# Altium schematic sheet AES-MS-MT3620-SK-G_PIO.SchDoc(AES-MS-MT3620-SK-G_PIO)
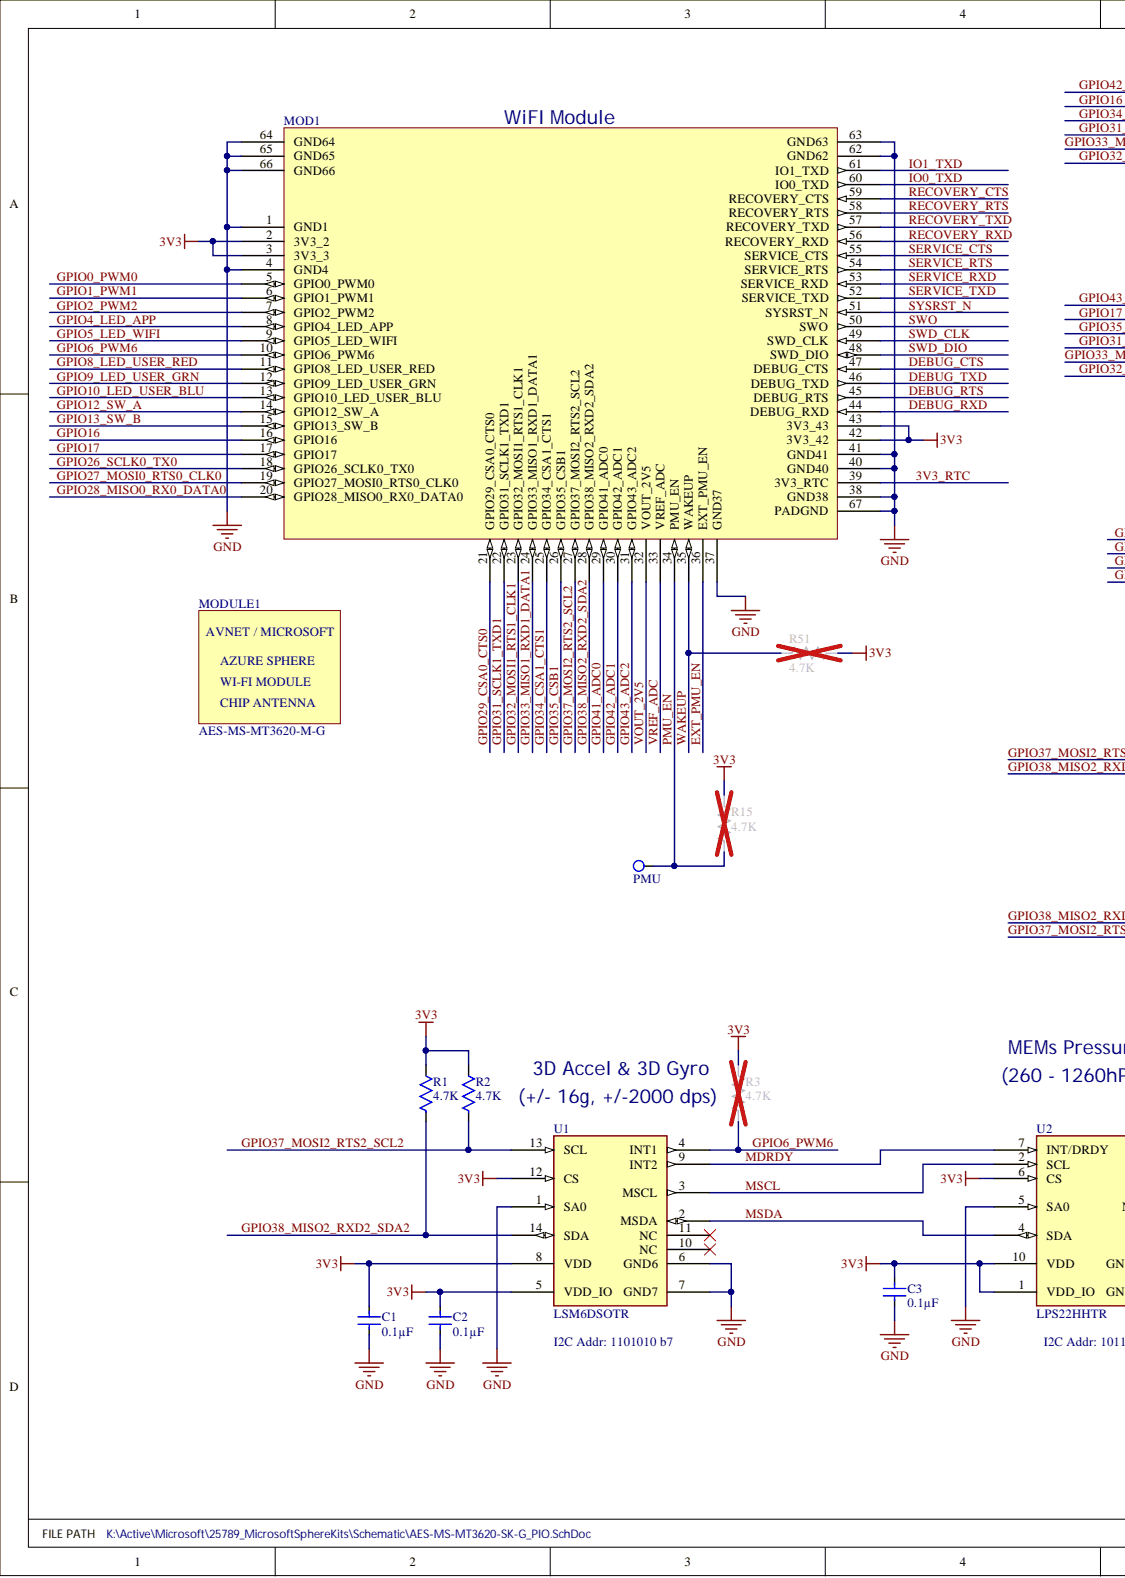
[diagram p0: AES-MS-MT3620-SK-G_PIO.SchDoc, left]
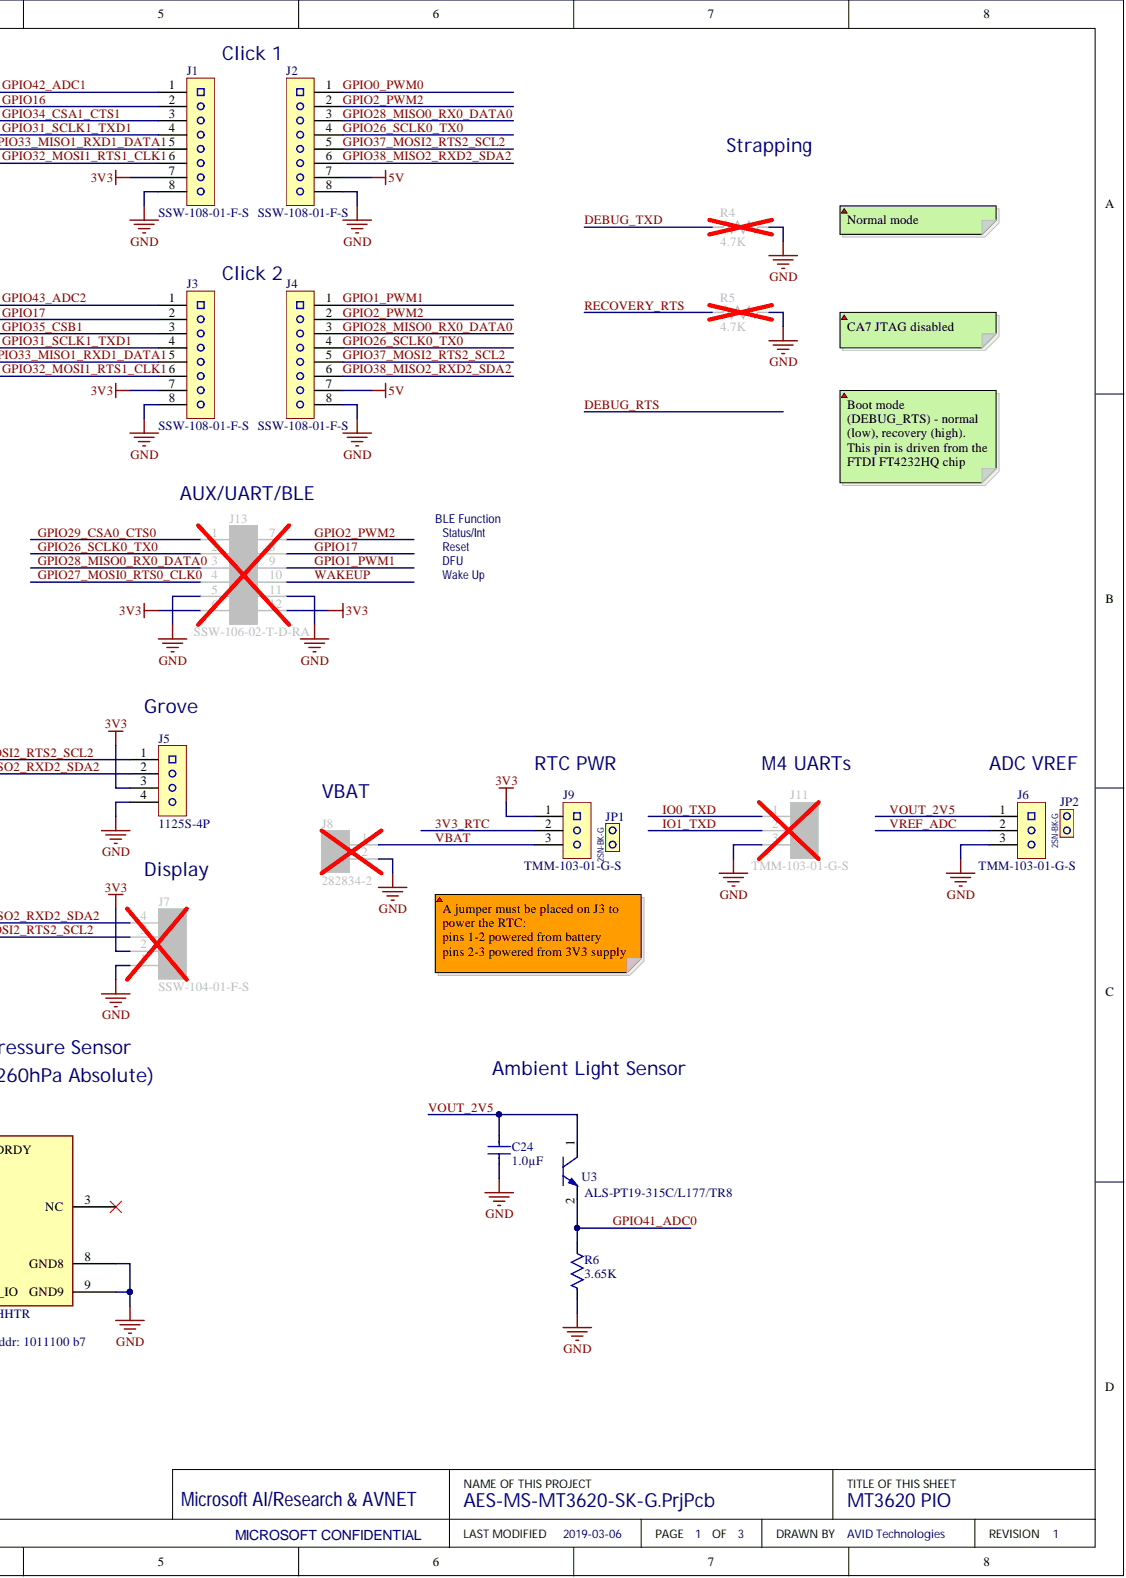
[diagram p1: AES-MS-MT3620-SK-G_PIO.SchDoc, right]

## Parts
C1  Capacitance: 0.1µF  [CAPC1005-EIA0402 0.55MM MED]  (hidden comment: 0.1uF)
C2  Capacitance: 0.1µF  [CAPC1005-EIA0402 0.55MM MED]  (hidden comment: 0.1uF)
C3  Capacitance: 0.1µF  [CAPC1005-EIA0402 0.55MM MED]  (hidden comment: 0.1uF)
C24  1.0µF  [CAPC1005-EIA0402 0.55MM MED]
J1  SSW-108-01-F-S  [SSW-108-01-F-S]
J2  SSW-108-01-F-S  [SSW-108-01-F-S]
J3  SSW-108-01-F-S  [SSW-108-01-F-S]
J4  SSW-108-01-F-S  [SSW-108-01-F-S]
J5  1125S-4P  [1125S-4P]
J6  TMM-103-01-G-S  [TMM-103-01-G-S]
J7  SSW-104-01-F-S  [SSW-104-01-F-S]
J8  282834-2  [282834-2-TB]
J9  TMM-103-01-G-S  [TMM-103-01-G-S]
J11  TMM-103-01-G-S  [TMM-103-01-G-S]
J13  SSW-106-02-T-D-RA  [CONN_SAMTEC_SSW-106-02-T-D-RA]
JP1  Manufacturer Part Number 1: 2SN-BK-G  (hidden comment: SHUNT 2POS 2mm)  (mechanical)
JP2  Manufacturer Part Number 1: 2SN-BK-G  (hidden comment: SHUNT 2POS 2mm)  (mechanical)
MOD1  MOD_AES-MS-MT3620  [AES-MS-MT3620-M-G_MODULE - 1.2]  (value not drawn)  (no BOM)
MODULE1  AES-MS-MT3620-M-G  Manufacturer 1: AVNET
PMU  TestPoint  [Pad1.27mm]  (value not drawn)  (no BOM)
R1  4.7K  [RES 0402 MEDIUM DENSITY]
R2  4.7K  [RES 0402 MEDIUM DENSITY]
R3  4.7K  [RES 0402 MEDIUM DENSITY]
R4  4.7K  [RES 0402 MEDIUM DENSITY]
R5  4.7K  [RES 0402 MEDIUM DENSITY]
R6  3.65K  [RES 0402 MEDIUM DENSITY]
R15  4.7K  [RES 0402 MEDIUM DENSITY]
R51  4.7K  [RES 0402 MEDIUM DENSITY]
U1  LSM6DSOTR  [LGA-14 2.5x3x0.86]
U2  LPS22HHTR  [LGA-10 2x2x0.8]
U3  ALS-PT19-315C/L177/TR8  [ALS-PT19-315C/L177/TR8]

## Nets
3V3: C1.2 C2.2 C3.2 J1.7 J3.7 J5.3 J7.2 J9.1 J13.6 J13.12 MOD1.2(3V3_2) MOD1.3(3V3_3) MOD1.42(3V3_42) MOD1.43(3V3_43) R1.1 R2.1 R3.1 R15.1 R51.1 U1.5(VDD_IO) U1.8(VDD) U1.12(CS) U2.1(VDD_IO) U2.6(CS) U2.10(VDD)
5V: J2.7 J4.7
GND: C1.1 C2.1 C3.1 C24.1 J1.8 J2.8 J3.8 J4.8 J5.4 J6.3 J7.1 J8.2 J11.3 J13.5 J13.11 MOD1.1(GND1) MOD1.4(GND4) MOD1.37(GND37) MOD1.38(GND38) MOD1.40(GND40) MOD1.41(GND41) MOD1.62(GND62) MOD1.63(GND63) MOD1.64(GND64) MOD1.65(GND65) MOD1.66(GND66) MOD1.67(PADGND) R4.1 R5.1 R6.2 U1.1(SA0) U1.6(GND6) U1.7(GND7) U2.5(SA0) U2.8(GND8) U2.9(GND9)
3V3_RTC: J9.2 MOD1.39(3V3_RTC)
DEBUG_CTS: MOD1.47(DEBUG_CTS)
DEBUG_RTS: MOD1.45(DEBUG_RTS)
DEBUG_RXD: MOD1.44(DEBUG_RXD)
DEBUG_TXD: MOD1.46(DEBUG_TXD) R4.2
EXT_PMU_EN: MOD1.36(EXT_PMU_EN)
GPIO0_PWM0: J2.1 MOD1.5(GPIO0_PWM0)
GPIO1_PWM1: J4.1 J13.9 MOD1.6(GPIO1_PWM1)
GPIO2_PWM2: J2.2 J4.2 J13.7 MOD1.7(GPIO2_PWM2)
GPIO4_LED_APP: MOD1.8(GPIO4_LED_APP)
GPIO5_LED_WIFI: MOD1.9(GPIO5_LED_WIFI)
GPIO6_PWM6: MOD1.10(GPIO6_PWM6) R3.2 U1.4(INT1)
GPIO8_LED_USER_RED: MOD1.11(GPIO8_LED_USER_RED)
GPIO9_LED_USER_GRN: MOD1.12(GPIO9_LED_USER_GRN)
GPIO10_LED_USER_BLU: MOD1.13(GPIO10_LED_USER_BLU)
GPIO12_SW_A: MOD1.14(GPIO12_SW_A)
GPIO13_SW_B: MOD1.15(GPIO13_SW_B)
GPIO16: J1.2 MOD1.16(GPIO16)
GPIO17: J3.2 J13.8 MOD1.17(GPIO17)
GPIO26_SCLK0_TX0: J2.4 J4.4 J13.2 MOD1.18(GPIO26_SCLK0_TX0)
GPIO27_MOSI0_RTS0_CLK0: J13.4 MOD1.19(GPIO27_MOSI0_RTS0_CLK0)
GPIO28_MISO0_RX0_DATA0: J2.3 J4.3 J13.3 MOD1.20(GPIO28_MISO0_RX0_DATA0)
GPIO29_CSA0_CTS0: J13.1 MOD1.21(GPIO29_CSA0_CTS0)
GPIO31_SCLK1_TXD1: J1.4 J3.4 MOD1.22(GPIO31_SCLK1_TXD1)
GPIO32_MOSI1_RTS1_CLK1: J1.6 J3.6 MOD1.23(GPIO32_MOSI1_RTS1_CLK1)
GPIO33_MISO1_RXD1_DATA1: J1.5 J3.5 MOD1.24(GPIO33_MISO1_RXD1_DATA1)
GPIO34_CSA1_CTS1: J1.3 MOD1.25(GPIO34_CSA1_CTS1)
GPIO35_CSB1: J3.3 MOD1.26(GPIO35_CSB1)
GPIO37_MOSI2_RTS2_SCL2: J2.5 J4.5 J5.1 J7.3 MOD1.27(GPIO37_MOSI2_RTS2_SCL2) R2.2 U1.13(SCL)
GPIO38_MISO2_RXD2_SDA2: J2.6 J4.6 J5.2 J7.4 MOD1.28(GPIO38_MISO2_RXD2_SDA2) R1.2 U1.14(SDA)
GPIO41_ADC0: MOD1.29(GPIO41_ADC0) R6.1 U3.2
GPIO42_ADC1: J1.1 MOD1.30(GPIO42_ADC1)
GPIO43_ADC2: J3.1 MOD1.31(GPIO43_ADC2)
IO0_TXD: J11.1 MOD1.60(IO0_TXD)
IO1_TXD: J11.2 MOD1.61(IO1_TXD)
MDRDY: U1.9(INT2) U2.7(INT/DRDY)
MSCL: U1.3(MSCL) U2.2(SCL)
MSDA: U1.2(MSDA) U2.4(SDA)
PMU_EN: MOD1.34(PMU_EN) PMU.1 R15.2
RECOVERY_CTS: MOD1.59(RECOVERY_CTS)
RECOVERY_RTS: MOD1.58(RECOVERY_RTS) R5.2
RECOVERY_RXD: MOD1.56(RECOVERY_RXD)
RECOVERY_TXD: MOD1.57(RECOVERY_TXD)
SERVICE_CTS: MOD1.55(SERVICE_CTS)
SERVICE_RTS: MOD1.54(SERVICE_RTS)
SERVICE_RXD: MOD1.53(SERVICE_RXD)
SERVICE_TXD: MOD1.52(SERVICE_TXD)
SWD_CLK: MOD1.49(SWD_CLK)
SWD_DIO: MOD1.48(SWD_DIO)
SWO: MOD1.50(SWO)
SYSRST_N: MOD1.51(SYSRST_N)
VBAT: J8.1 J9.3
VOUT_2V5: C24.2 J6.1 MOD1.32(VOUT_2V5) U3.1
VREF_ADC: J6.2 MOD1.33(VREF_ADC)
WAKEUP: J13.10 MOD1.35(WAKEUP) R51.2

Not connected: U1 10(NC) 11(NC); U2 3(NC)

## Library data of the parts
C1-C3 = 0.1µF 0402 X7R 50V: CAP CER 0.1UF 25V 10% X5R 0402; Manufacturer Part Number 1: CL05A104KA5NNNC; Manufacturer 1: Samsung; Width: 0.02inch; Voltage Rating (DC): 25V; Voltage Rating: 25V; Voltage: 25V; Tolerance: 10%; Thickness: 0.022inch; Supplier Part Number 1: 1276-1043-1-ND; Supplier 1: Digi-Key; Stock 1: 100000; Radiation Hardening: No; Pricing 1: 1=0.1, 10=0.032, 100=0.0141, 500=0.0101, 1000=0.0079, 2500=0.0072, 5000=0.0066 (USD); Packaging: Tape and Reel; Package Quantity: 1; Mount: Surface Mount; Min Operating Temperature: -55°C; Max Operating Temperature: 85°C; Length: 1mm; Lead Free: Lead Free; Height: 0.5mm; Dielectric: X5R; Depth: 0.5mm; Component Kind: Standard; Case Code (Metric): 1005; Case Code (Imperial): 0402; Case/Package: 0402; Library Name: Sphere.SCHLIB
C24 = 1.0µF 0402 X5R 35V: CAP CER 1UF 35V 10% X5R 0402; Manufacturer Part Number 1: C1005X5R1V105K050BC; Manufacturer 1: TDK Corporation; Voltage - Rated: 35V; Tolerance: ±10%; Thickness (Max): 0.022" (0.55mm); Temperature Coefficient: X5R; Supplier Part Number 1: 445-9073-2-ND; Supplier 1: Digi-Key; Stock 1: 47833; Size / Dimension: 0.039" L x 0.020" W (1.00mm x 0.50mm); RoHS: RoHS Compliant; Ratings: -; Pricing 1: 0.0186; Packaging: Tape & Reel (TR); Package / Case: 0402 (1005 Metric); Operating Temperature: -55°C ~ 125°C; Mounting Type: Surface Mount, MLCC; Lead Style: -; Lead Spacing: -; Height - Seated (Max): -; Features: -; Component Kind: Standard; Category: Capacitors; Capacitance: 1.0µF; Applications: General Purpose; Library Name: Sphere.SCHLIB
J1-J4 = CONN 8 POS SSW-108-01-F-S: CONN RCPT .100" 8POS SNGL GOLD; Manufacturer 1: Samtec; Pitch: 2.54mm; Number of Contacts: 8; Max Voltage Rating (AC): 550V; Max Current Rating: 7.6A; Mating Cycles: 100; Gender: Female; Contact Resistance: 10mR; Termination: Solder; Supplier Part Number 1: SAM11943-ND; Supplier 1: Digi-Key; Stock 1: 256; Radiation Hardening: No; Pricing 1: 1=1.19, 10=0.988, 100=0.732, 500=0.5856, 1000=0.5307, 5000=0.4667, 10000=0.4575 (USD); Packaging: Bulk; Orientation: Straight; Number of Rows: 1; Min Operating Temperature: -55°C; Max Operating Temperature: 125°C; Manufacturer Part Number 1: SSW-108-01-F-S; Length: 20.83mm; Housing Material: Polymer; Housing Colour: Black; Depth: 2.41mm; Contact Plating: Gold; Contact Material: Bronze; Component Kind: Standard; Colour: Black; Library Name: Sphere.SCHLIB
J5 = CONN_4POS_2mm_1125S-4P: CONN HEADER 4POS 2mm VERT; Manufacturer 1: NS-TECH; Supplier Part Number 1: 110990046-ND; Supplier 1: Digi-Key; Manufacturer Part Number 1: 1125S-4P; Component Kind: Standard; Library Name: Sphere.SCHLIB
J6 J9 J11 = CONN 3 POS PINHDR 2MM: CONN HEADER 3POS SNGL 2MM T/H; Manufacturer 1: Samtec; Voltage Rating: 500V; Number of Positions: 3; Max Current Rating: 3.9A; Gender: Male; Connector Type: Male Pin; Termination: Solder; Supplier Part Number 1: SAM1174-03-ND; Supplier 1: Digi-Key; Stock 1: 14838; REACH SVHC: No SVHC; Radiation Hardening: No; Pricing 1: 1=1.76, 10=1.526, 100=1.2908, 500=1.0268, 1000=0.8361, 5000=0.7628, 10000=0.7334 (USD); Pitch: 2mm; Packaging: Bulk; Package Quantity: 1; Orientation: Straight; Number of Rows: 1; Number of Contacts: 3; Mount: Through Hole; Min Operating Temperature: -55°C; Max Voltage Rating (AC): 500V; Max Operating Temperature: 125°C; Manufacturer Part Number 1: TMM-103-01-G-S; Length: 6mm; Lead Free: Lead Free; Housing Material: Polymer; Housing Colour: Black; Depth: 1.97mm; Current Rating: 3.9A; Contact Plating: Gold; Contact Mating Length: 0.126inch; Contact Material: Bronze; Component Kind: Standard; Colour: Black; Library Name: Sphere.SCHLIB
J7 = CONN 4 POS SSW-104-01-F-S: CONN RCPT .100" 4POS SNGL GOLD; Manufacturer 1: Samtec; Pitch: 2.54mm; Number of Contacts: 4; Max Voltage Rating (AC): 550V; Max Current Rating: 6.9A; Mating Cycles: 100; Gender: Female; Contact Resistance: 10mR; Termination: Solder; Supplier Part Number 1: SAM10018-ND; Supplier 1: Digi-Key; Stock 1: 3016; Radiation Hardening: No; Pricing 1: 1=0.83, 10=0.691, 100=0.512, 500=0.4096, 1000=0.3712, 5000=0.3264, 10000=0.32 (USD); Packaging: Bulk; Orientation: Straight; Number of Rows: 1; Min Operating Temperature: -55°C; Max Operating Temperature: 125°C; Manufacturer Part Number 1: SSW-104-01-F-S; Length: 10.67mm; Housing Material: Polymer; Housing Colour: Black; Depth: 2.41mm; Contact Plating: Gold; Contact Material: Bronze; Component Kind: Standard; Colour: Black; Library Name: Sphere.SCHLIB
J8 = CONN 2 POS TB 282834-2: TERM BLOCK 2POS SIDE ENT 2.54MM; Manufacturer 1: TE Connectivity; Wire Gauge (Min): 30AWG; Voltage Rating: 150V; Thread Size: M1.6; Tail Length: 3.4mm; Side Stackable: Yes (With); Screw Flange: No (Without); Plating: Brass,Steel; Number of Positions Per Level: 2; Number of Levels: 1; Max Current Rating: 10A; Gender: Male; Connector Type: Receptacle; Approvals: UL; Wire Gauge (Max): 30AWG; Wire/Cable Gauge: 30AWG; Width: 6.5mm; Voltage: 150V; Termination: Solder; Supplier Part Number 1: A98333-ND; Supplier 1: Digi-Key; Stock 1: 3162; Screwless Terminal Block: Yes (With); REACH SVHC: Unknown; Radiation Hardening: No; Pricing 1: 1=0.88, 10=0.62, 25=0.5784, 50=0.525, 100=0.5228, 250=0.4414, 500=0.4182, 1000=0.3718, 5000=0.3485 (USD); Pitch: 2.54mm; Packaging: Bulk; Package Quantity: 300; Orientation: Straight; Number of Rows: 1; Number of Positions: 2; Number of Poles: 2; Number of Contacts: 2; Number of Circuits: 2; Mount: Through Hole; Min Operating Temperature: -40°C; Max Voltage Rating (AC): 150V; Max Supply Voltage (AC): 150V; Max Operating Temperature: 105°C; Material: Nylon; Manufacturer Part Number 1: 282834-2; Length: 5.54mm; Lead Free: Lead Free; Interlock: No (Without); Housing Material: Polyamide; Housing Colour: Green; Flammability Rating: UL94 V-0; ELV: Compliant; Depth: 6.5mm; Current Rating: 10A; Current: 10A; Contact Plating: Tin; Contact Material: Brass; Component Kind: Standard; Colour: Green; Closed End: No (Without); Library Name: Sphere.SCHLIB
J13 = CONN 12 POS RA SSW-106-02-T-D-RA: CONN RCPT 12POS 0.1 TIN PCB R/A; Manufacturer 1: Samtec; Pitch: 2.54mm; Number of Contacts: 12; Max Voltage Rating (AC): 550V; Max Current Rating: 6.9A; Mating Cycles: 100; Gender: Receptacle; Contact Resistance: 10mR; Termination: Solder; Supplier Part Number 1: SAM10026-ND; Supplier 1: Digi-Key; Stock 1: 48; REACH SVHC: No SVHC; Radiation Hardening: No; Pricing 1: 1=1.34, 10=1.112, 100=0.824, 500=0.6592, 1000=0.5974, 5000=0.5253, 10000=0.515 (USD); Packaging: Bulk; Orientation: Right Angle; Number of Rows: 2; Min Operating Temperature: -55°C; Max Operating Temperature: 105°C; Manufacturer Part Number 1: SSW-106-02-T-D-RA; Length: 15.75mm; Housing Material: Polymer; Housing Color: Black; Depth: 12.57mm; Contact Plating: Matte,Tin; Contact Material: Bronze; Component Kind: Standard; Color: Black; Library Name: Sphere.SCHLIB
JP1 JP2 = SHUNT 2POS 2mm: SAMTEC         2SN-BK-G            JUMPER, 2MM, BLACK; Number of Positions: 2; Max Current Rating: 2.5A; Contact Resistance: 5mR; Supplier Part Number 1: SAM8748-ND; Supplier 1: Digi-Key; Stock 1: 27703; REACH SVHC: No SVHC; Radiation Hardening: No; Pricing 1: 1=0.33, 10=0.315, 25=0.27, 50=0.2296, 100=0.2205, 250=0.198, 500=0.189, 1000=0.1575, 2500=0.144 (USD); Pitch: 2mm; Packaging: Bulk; Package Quantity: 1; Orientation: Straight; Number of Rows: 1; Number of Contacts: 2; Min Operating Temperature: -40°C; Max Operating Temperature: 90°C; Manufacturer 1: Samtec; Length: 3.99mm; Housing Material: Polyester; Housing Color: Black; Height: 0.175inch; Depth: 2mm; Contact Plating: Gold; Contact Material: Bronze; Color: Black; Library Name: Sphere.SCHLIB
MOD1 = MOD_AES-MS-MT3620: AES-MS-MT3620 FOOTPRINT; Library Name: Sphere.SCHLIB
MODULE1 = MODULE AES-MS-MT3620-M-G: WIFI Azure Sphere Module 22x33; Manufacturer Part Number 1: AES-MS-MT3620-M-G; Library Name: Sphere.SCHLIB; Component Kind: Standard
PMU = TestPoint: Test Point; Library Name: generic.SchLib
R1-R5 R15 R51 = 4.7K 0402: RES 4.7K OHM 1/10W 1% 0402 SMD; Manufacturer Part Number 1: ERJ-2RKF4701X; Manufacturer 1: Panasonic; Temperature Coefficient: ±100ppm/°C; Power (Watts): 0.1W, 1/10W; Tolerance: ±1%; Supplier Part Number 1: P4.70KLCT-ND; Supplier Device Package: 0402; Supplier 1: Digi-Key; Stock 1: 1251398; Size / Dimension: 0.039" L x 0.020" W (1.00mm x 0.50mm); RoHS: RoHS Compliant; Resistance (Ohms): 4.7K; Pricing 1: 1=0.07, 50=0.0128, 100=0.0097, 250=0.0072, 500=0.00532, 1000=0.00355, 5000=0.0025 (GBP); Packaging: Cut Tape (CT); Package / Case: 0402 (1005 Metric); Number of Terminations: 2; Height: 0.016" (0.40mm); Features: -; Composition: Thick Film; Component Kind: Standard; Category: Resistors; Library Name: Sphere.SCHLIB
R6 = 3.65K 0402: RES 3.65K OHM 1/10W 1% 0402 SMD; Manufacturer Part Number 1: ERJ-2RKF3651X; Manufacturer 1: Panasonic; Voltage Rating (DC): 50V; Temperature Coefficient: 100ppm/°C; Power Rating: 100mW; Width: 0.02inch; Voltage Rating: 50V; Tolerance: ±1%; Termination: SMD/SMT; Supplier Part Number 1: P3.65KLCT-ND; Supplier 1: Digi-Key; Stock 1: 109425; Resistance (Ohms): 3.65K; REACH SVHC: Yes; Pricing 1: 1=0.1, 10=0.045, 100=0.0184, 1000=0.0083, 2500=0.0072, 5000=0.0059 (USD); Packaging: Tape and Reel; Package Quantity: 1; Number of Terminations: 2; Mount: Surface Mount; Min Operating Temperature: -55°C; Military Standard: Not; Max Power Dissipation: 100mW; Max Operating Temperature: 155°C; Length: 1mm; Lead Free: Lead Free; Height: 0.35mm; Features: Automotive AEC-Q200; Depth: 0.5mm; Contact Plating: Tin; Composition: Thick Film; Component Kind: Standard; Case Code (Metric): 1005; Case Code (Imperial): 0402; Case/Package: 0402; Library Name: Sphere.SCHLIB
U1 = IC_LSM6DSOTR: IC IMU ACCEL/GYRO I2C/SPI 14LGA; Manufacturer 1: STMicroelectronics; Supplier Part Number 1: 497-18181-1-ND; Supplier 1: Digi-Key; Stock 1: 329; Pricing 1: 1=4.23, 10=3.8, 100=3.1132 (USD); Manufacturer Part Number 1: LSM6DSOTR; Component Kind: Standard; Library Name: Sphere.SCHLIB
U2 = IC_LPS22HHTR: IC MEMS NANO PRESSURE SENSOR 10WFLGA; Manufacturer 1: STMicroelectronics; Supplier Part Number 1: 497-18182-1-ND; Supplier 1: Digi-Key; Stock 1: 500; Pricing 1: 1=3.28, 10=2.942, 100=2.4102 (USD); Manufacturer Part Number 1: LPS22HHTR; Component Kind: Standard; Library Name: Sphere.SCHLIB
U3 = IC_ALS-PT19-315C: LIGHT SENSOR AMBIENT SMD; Manufacturer 1: Everlight; Wavelength: 630nm; Rise Time: 0.11ms; Polarity: NPN; Number of Elements: 1; Max Breakdown Voltage: 5.5V; Lens Style: Flat; Fall Time: 0.22ms; Dark Current: 100nA; Supplier Part Number 1: 1080-1244-1-ND; Supplier 1: Digi-Key; Stock 1: 137493; Radiation Hardening: No; Pricing 1: 1=0.43, 5=0.41, 10=0.364, 25=0.3348, 50=0.268, 100=0.2297, 500=0.201, 1000=0.1723 (USD); Packaging: Tape and Reel; Package Quantity: 1; Number of Pins: 2; Mount: Surface Mount; Min Operating Temperature: -40°C; Max Operating Temperature: 85°C; Manufacturer Part Number 1: ALS-PT19-315C/L177/TR8; Component Kind: Standard; Collector Emitter Breakdown Voltage: 5.5V; Case/Package: SMD/SMT; Library Name: Sphere.SCHLIB
